# Altium schematic sheet Power.SchDoc(Power) (continued)
## Library data of the parts (continued)
R10 = RC0603JR-07300RL: Res Thick Film 0603 300 Ohm 5% 0.1W(1/10W) ±100ppm/C Pad SMD T/R; Manufacturer: Yageo Group; Power Dissipation: 100mW; Temperature Coefficient: 100ppm/°C; Value: 300Ω; Voltage Rating: 75V; Voltage Rating (DC): 50V; Case Code (Imperial): 0603; Case/Package: 0603; Category: Chip SMD Resistors; China RoHS: Non-Compliant; Component Kind: Standard; ComponentLink1Description: https://datasheet.octopart.com:443/RC0603JR-07300RL-Yageo-datasheet-175887523.pdf?src-supplier=IHS; ComponentLink1URL: https://datasheet.octopart.com:443/RC0603JR-07300RL-Yageo-datasheet-175887523.pdf?src-supplier=IHS; ComponentLink2Description: https://datasheet.octopart.com:443/RC0603JR-07300RL-Yageo-datasheet-98239111.pdf?src-supplier=Upverter; ComponentLink2URL: https://datasheet.octopart.com:443/RC0603JR-07300RL-Yageo-datasheet-98239111.pdf?src-supplier=Upverter; ComponentLink3Description: https://datasheet.octopart.com:443/RC0603JR-07300RL-Yageo-datasheet-8328172.pdf?src-supplier=TME; ComponentLink3URL: https://datasheet.octopart.com:443/RC0603JR-07300RL-Yageo-datasheet-8328172.pdf?src-supplier=TME; ComponentLink4Description: https://datasheet.octopart.com:443/RC0603JR-07300RL-Yageo-datasheet-34211833.pdf?src-supplier=element14+APAC; ComponentLink4URL: https://datasheet.octopart.com:443/RC0603JR-07300RL-Yageo-datasheet-34211833.pdf?src-supplier=element14+APAC; ComponentLink5Description: https://datasheet.octopart.com:443/RC0603JR-07300RL-Yageo-datasheet-62067350.pdf?src-supplier=Farnell; ComponentLink5URL: https://datasheet.octopart.com:443/RC0603JR-07300RL-Yageo-datasheet-62067350.pdf?src-supplier=Farnell; ComponentLink6Description: https://datasheet.octopart.com:443/RC0603JR-07300RL-Yageo-Group-datasheet-176638170.pdf?src-supplier=Future+Electronics; ComponentLink6URL: https://datasheet.octopart.com:443/RC0603JR-07300RL-Yageo-Group-datasheet-176638170.pdf?src-supplier=Future+Electronics; ComponentLink7Description: https://datasheet.octopart.com:443/RC0603JR-07300RL-Yageo-datasheet-164759695.pdf?src-supplier=Future+Electronics; ComponentLink7URL: https://datasheet.octopart.com:443/RC0603JR-07300RL-Yageo-datasheet-164759695.pdf?src-supplier=Future+Electronics; ComponentLink8Description: https://datasheet.octopart.com:443/RC0603JR-07300RL-Yageo-datasheet-137700087.pdf?src-supplier=Future+Electronics; ComponentLink8URL: https://datasheet.octopart.com:443/RC0603JR-07300RL-Yageo-datasheet-137700087.pdf?src-supplier=Future+Electronics; ComponentLink9Description: https://datasheet.octopart.com:443/RC0603JR-07300RL-Yageo-datasheet-15599030.pdf?src-supplier=Future+Electronics; ComponentLink9URL: https://datasheet.octopart.com:443/RC0603JR-07300RL-Yageo-datasheet-15599030.pdf?src-supplier=Future+Electronics; ComponentLink10Description: https://datasheet.octopart.com:443/RC0603JR-07300RL-Yageo-datasheet-12596169.pdf?src-supplier=Future+Electronics; ComponentLink10URL: https://datasheet.octopart.com:443/RC0603JR-07300RL-Yageo-datasheet-12596169.pdf?src-supplier=Future+Electronics; ComponentLink11Description: https://datasheet.octopart.com:443/RC0603JR-07300RL-Yageo-datasheet-8324193.pdf?src-supplier=Future+Electronics; ComponentLink11URL: https://datasheet.octopart.com:443/RC0603JR-07300RL-Yageo-datasheet-8324193.pdf?src-supplier=Future+Electronics; ComponentLink12Description: https://datasheet.octopart.com/RC0603JR-07300RL-Yageo-datasheet-73766026.pdf; ComponentLink12URL: https://datasheet.octopart.com/RC0603JR-07300RL-Yageo-datasheet-73766026.pdf; ComponentLink13Description: https://datasheet.octopart.com:443/RC0603JR-07300RL-Yageo-datasheet-118562.pdf?src-supplier=DigiKey; ComponentLink13URL: https://datasheet.octopart.com:443/RC0603JR-07300RL-Yageo-datasheet-118562.pdf?src-supplier=DigiKey; ComponentLink14Description: http://sigma.octopart.com:80/conflict_mineral_statement/Yageo-company-3.pdf?src-supplier=Compliance+Statements; ComponentLink14URL: http://sigma.octopart.com:80/conflict_mineral_statement/Yageo-company-3.pdf?src-supplier=Compliance+Statements; ComponentLink15Description: http://sigma.octopart.com:80/rohs_statement/Yageo-company-2.pdf?src-supplier=Compliance+Statements; ComponentLink15URL: http://sigma.octopart.com:80/rohs_statement/Yageo-company-2.pdf?src-supplier=Compliance+Statements; Composition: Thick Film; Country of Origin: Mainland China, Taiwan; Depth: 800µm; Export Control Classification Number (ECCN) Code: EAR99; Harmonized Tariff Schedule (HTS) Code: 8533.21.00.30; Height: 450µm; Introduction Date: 2004-09-22; Lead Free: Lead Free; Length: 1.6mm; Library Name: ENGG3800 schematic library.SchLib; Lifecycle Status: Production; Manufacturer Part Number: RC0603JR-07300RL; Max Operating Temperature: 155°C; Military Standard: Not; Min Operating Temperature: -55°C; Mounting Technology: Surface Mount; Number of Terminals: 2; Pins: 2; Power Rating: 100mW; Pricing: 5000=0.0015, 10000=0.0012 (USD); REACH SVHC: Yes; RoHS: Compliant; Schedule B: 8533210045, 8533210045|8533210045|8533210045|8533210045|8533210045|8533210045|8533210045|8533210045|8533210045|8533210045|8533210045|8533210045|8533210045|8533210045|8533210045|8533210045|8533210045|8533210045|8533210045|8533210045|8533210045|8533210045|8533210045|85; Stock: 640000; Supplier 1: Digikey; Supplier Part Number 1: 311-300GRCT-ND; Termination: SMD/SMT; Weight: 2.012816mg; Width: 800µm; Working Voltage: 75V
SW1 = CS22BNW03: Switch Slide ON None ON DPDT Top Slide 3A 250VAC 50000Cycles PC Pins Thru-Hole; Manufacturer: NKK Switches; Actuator Length: 5.1054mm; Actuator Material: Glass, Glass fibre, Nylon, Polyamide; Contact Resistance: 10mΩ; Contact Style: Non-Shorting; Contact Voltage Rating (AC): 125V; Electrical Life: 20kcycles; Illumination Type: Non-Illuminated; Insulation Resistance: 1GΩ; Max Voltage Rating (AC): 250V; Mechanical Life: 50kcycles; Number of Positions: 2; Sealable: EPOXY TERMINAL SEALED; Switch Function: On-On; Switch Travel: 2.2mm; Category: Slide Switches; China RoHS: Compliant; Component Kind: Standard; ComponentLink1Description: https://datasheet.octopart.com:443/CS22BNW03-NKK-Switches-datasheet-12526506.pdf?src-supplier=Upverter; ComponentLink1URL: https://datasheet.octopart.com:443/CS22BNW03-NKK-Switches-datasheet-12526506.pdf?src-supplier=Upverter; ComponentLink2Description: https://datasheet.octopart.com:443/CS22BNW03-NKK-Switches-datasheet-123473.pdf?src-supplier=Future+Electronics; ComponentLink2URL: https://datasheet.octopart.com:443/CS22BNW03-NKK-Switches-datasheet-123473.pdf?src-supplier=Future+Electronics; ComponentLink3Description: https://datasheet.octopart.com:443/CS22BNW03-NKK-Switches-datasheet-110754214.pdf?src-supplier=TME; ComponentLink3URL: https://datasheet.octopart.com:443/CS22BNW03-NKK-Switches-datasheet-110754214.pdf?src-supplier=TME; ComponentLink4Description: https://datasheet.octopart.com:443/CS22BNW03-NKK-Switches-datasheet-137092470.pdf?src-supplier=X-ON; ComponentLink4URL: https://datasheet.octopart.com:443/CS22BNW03-NKK-Switches-datasheet-137092470.pdf?src-supplier=X-ON; ComponentLink5Description: http://sigma.octopart.com:80/rohs_statement/NKK-Switches-company-77.pdf?src-supplier=Compliance+Statements; ComponentLink5URL: http://sigma.octopart.com:80/rohs_statement/NKK-Switches-company-77.pdf?src-supplier=Compliance+Statements; ComponentLink6Description: http://sigma.octopart.com:80/reach_statement/NKK-Switches-company-76.pdf?src-supplier=Compliance+Statements; ComponentLink6URL: http://sigma.octopart.com:80/reach_statement/NKK-Switches-company-76.pdf?src-supplier=Compliance+Statements; ComponentLink7Description: http://sigma.octopart.com:80/conflict_mineral_statement/NKK-Switches-company-75.pdf?src-supplier=Compliance+Statements; ComponentLink7URL: http://sigma.octopart.com:80/conflict_mineral_statement/NKK-Switches-company-75.pdf?src-supplier=Compliance+Statements; Contact Current Rating: 3A; Contact Material: Copper; Contact Plating: Silver; Current Rating: 3A; Depth: 11.9mm; Export Control Classification Number (ECCN) Code: EAR99; Flammability Rating: UL94 V-0; Harmonized Tariff Schedule (HTS) Code: 8536.50.90.50; Height: 9.7mm; Introduction Date: 2010-05-04; Lead Free: Lead Free; Length: 14mm; Library Name: ENGG3800 schematic library.SchLib; Life (Cycles): 20kcycles; Lifecycle Status: Production; Manufacturer Part Number: CS22BNW03; Max Current Rating: 3A; Max Operating Temperature: 85°C; Min Operating Temperature: -30°C; Mounting Technology: Through Hole; Orientation: Vertical; Pricing: 50=1.47, 150=1.46, 500=1.44, 1000=1.42, 2000=1.4 (USD); REACH SVHC: Yes; RoHS: Compliant; Stock: 789; Supplier 1: Digikey; Supplier Part Number 1: 360-2132-ND; Terminal Material: Copper; Termination: PC Pins; Throw Configuration: DPDT; Voltage Rating (AC): 125V
TP1 = 5010: MS27478 - Connectors Receptacle Electrical Solder Mounting Solder Type Hermetic Seal 38999 Series II; Manufacturer: Keystone Electronics; Body Material: Bronze,PBT; Hole Diameter: 1.6mm; Inside Diameter: 1.78mm; Plating: Silver; Category: Connectors; Color: Red; Component Kind: Standard; ComponentLink1Description: https://datasheet.ciiva.com/11744/0900766b8009b7c7-11744920.pdf?src-supplier=Rs; ComponentLink1URL: https://datasheet.ciiva.com/11744/0900766b8009b7c7-11744920.pdf?src-supplier=Rs; ComponentLink2Description: https://datasheet.ciiva.com/2917/5010-2917626.pdf?src-supplier=Digikey; ComponentLink2URL: https://datasheet.ciiva.com/2917/5010-2917626.pdf?src-supplier=Digikey; ComponentLink3Description: https://datasheet.ciiva.com/1454/1703983-1454833.pdf?src-supplier=Element14; ComponentLink3URL: https://datasheet.ciiva.com/1454/1703983-1454833.pdf?src-supplier=Element14; ComponentLink4Description: https://datasheet.ciiva.com/22631/1703983-22631113.pdf?src-supplier=Element14; ComponentLink4URL: https://datasheet.ciiva.com/22631/1703983-22631113.pdf?src-supplier=Element14; ComponentLink5Description: https://datasheet.ciiva.com/16506/m60-2p60-16506972.pdf?src-supplier=Future+Electronics; ComponentLink5URL: https://datasheet.ciiva.com/16506/m60-2p60-16506972.pdf?src-supplier=Future+Electronics; ComponentLink6Description: https://datasheet.ciiva.com/16883/70182825-16883886.pdf?src-supplier=Allied+Electronics; ComponentLink6URL: https://datasheet.ciiva.com/16883/70182825-16883886.pdf?src-supplier=Allied+Electronics; Contact Material: Bronze; Contact Plating: Silver,Tin; Flammability Rating: UL94 V-0; Height: 0.445inch; Insulation Material: Nylon; Lead Free: Lead Free; Length: 11.3mm; Manufacturer Part Number: 5010; Material: Nylon,Bronze,Silver; Mounting Technology: Through Hole,PCB; Package Quantity: 100; Packaging: Bulk; Pricing: 1=0.39, 10=0.293, 25=0.2624, 100=0.2223, 500=0.18446, 1000=0.1739, 2500=0.1542, 5000=0.14312 (USD); Reach SVHC Compliant: No; RoHS: Yes; RoHS Compliant: Yes; Series: THM; Stock: 151577; Supplier 1: Digikey; Supplier Part Number 1: 36-5010-ND; Termination: Through Hole; Library Name: tpmania.SchLib
TP2 = 5012: Test Point, Multipurpose, Thm, .350 L, .125 Od, Heat Resistant Nylon 46, White; Manufacturer: Keystone; Body Material: Bronze, PBT; Gender: Female; Hole Diameter: 1.6mm; Inside Diameter: 1.78mm; Insulation: Insulated; Number of Contacts: 1; Case/Package: 5012; Category: Test Points; Color: White; Component Kind: Standard; ComponentLink1Description: https://datasheet.octopart.com:443/5012-Keystone-datasheet-111020743.pdf?src-supplier=IHS; ComponentLink1URL: https://datasheet.octopart.com:443/5012-Keystone-datasheet-111020743.pdf?src-supplier=IHS; ComponentLink2Description: https://datasheet.octopart.com:443/5012-Keystone-datasheet-15716159.pdf?src-supplier=Farnell; ComponentLink2URL: https://datasheet.octopart.com:443/5012-Keystone-datasheet-15716159.pdf?src-supplier=Farnell; ComponentLink3Description: https://datasheet.octopart.com:443/5012-Keystone-datasheet-9590016.pdf?src-supplier=Sager+Electronics; ComponentLink3URL: https://datasheet.octopart.com:443/5012-Keystone-datasheet-9590016.pdf?src-supplier=Sager+Electronics; ComponentLink4Description: https://datasheet.octopart.com:443/5012-Keystone-datasheet-23674.pdf?src-supplier=element14; ComponentLink4URL: https://datasheet.octopart.com:443/5012-Keystone-datasheet-23674.pdf?src-supplier=element14; ComponentLink5Description: https://datasheet.octopart.com:443/5012-Keystone-datasheet-549598.pdf?src-supplier=Future+Electronics; ComponentLink5URL: https://datasheet.octopart.com:443/5012-Keystone-datasheet-549598.pdf?src-supplier=Future+Electronics; ComponentLink6Description: https://datasheet.octopart.com:443/5012-Keystone-datasheet-60278094.pdf?src-supplier=Future+Electronics; ComponentLink6URL: https://datasheet.octopart.com:443/5012-Keystone-datasheet-60278094.pdf?src-supplier=Future+Electronics; ComponentLink7Description: https://datasheet.octopart.com:443/5012-Keystone-datasheet-528293.pdf?src-supplier=Future+Electronics; ComponentLink7URL: https://datasheet.octopart.com:443/5012-Keystone-datasheet-528293.pdf?src-supplier=Future+Electronics; ComponentLink8Description: https://datasheet.octopart.com:443/5012-Keystone-datasheet-10925273.pdf?src-supplier=RS+(Formerly+Allied+Electronics); ComponentLink8URL: https://datasheet.octopart.com:443/5012-Keystone-datasheet-10925273.pdf?src-supplier=RS+(Formerly+Allied+Electronics); ComponentLink9Description: https://datasheet.octopart.com:443/5012-Keystone-datasheet-6528.pdf?src-supplier=RS+(Formerly+Allied+Electronics); ComponentLink9URL: https://datasheet.octopart.com:443/5012-Keystone-datasheet-6528.pdf?src-supplier=RS+(Formerly+Allied+Electronics); ComponentLink10Description: https://datasheet.octopart.com:443/5012-Keystone-datasheet-7282928.pdf?src-supplier=Jameco; ComponentLink10URL: https://datasheet.octopart.com:443/5012-Keystone-datasheet-7282928.pdf?src-supplier=Jameco; Contact Material: Bronze; Contact Plating: Silver; Country of Origin: Mainland China; Diameter: 3.18mm; Export Control Classification Number (ECCN) Code: EAR99; Flammability Rating: UL94 V-0; Height: 8.12mm; Housing Color: White; Introduction Date: 1995-10-01; Lead Free: Lead Free; Length: 11.3mm; Lifecycle Status: Production; Manufacturer Part Number: 5012; Material: Bronze, Nylon, Silver; Mounting Technology: Through Hole; Number of Ports: 1; Orientation: Straight; Packaging: Bulk; Pricing: 125=0.184, 250=0.181, 500=0.178, 1250=0.175, 2500=0.17 (USD); REACH SVHC: Yes; RoHS: Compliant; Stock: 1107; Supplier 1: Digikey; Supplier Part Number 1: 36-5012-ND; Termination: Through Hole; Library Name: tpmania.SchLib
TP3 = 5013: Test Point, Multipurpose, Thm, .350 L, .125 Od, Heat Resistant Nylon 46, Orange; Manufacturer: Keystone; Body Material: PBT; Inside Diameter: 1.78mm; Category: Test Points; Color: Orange; Component Kind: Standard; ComponentLink1Description: https://datasheet.octopart.com:443/5013-Keystone-datasheet-111020744.pdf?src-supplier=IHS; ComponentLink1URL: https://datasheet.octopart.com:443/5013-Keystone-datasheet-111020744.pdf?src-supplier=IHS; ComponentLink2Description: https://datasheet.octopart.com:443/5013-Keystone-datasheet-15716159.pdf?src-supplier=Farnell; ComponentLink2URL: https://datasheet.octopart.com:443/5013-Keystone-datasheet-15716159.pdf?src-supplier=Farnell; ComponentLink3Description: https://datasheet.octopart.com:443/5013-Keystone-datasheet-23674.pdf?src-supplier=element14; ComponentLink3URL: https://datasheet.octopart.com:443/5013-Keystone-datasheet-23674.pdf?src-supplier=element14; ComponentLink4Description: https://datasheet.octopart.com:443/5013-Keystone-datasheet-9590016.pdf?src-supplier=Sager+Electronics; ComponentLink4URL: https://datasheet.octopart.com:443/5013-Keystone-datasheet-9590016.pdf?src-supplier=Sager+Electronics; ComponentLink5Description: https://datasheet.octopart.com:443/5013-Keystone-datasheet-60278094.pdf?src-supplier=Future+Electronics; ComponentLink5URL: https://datasheet.octopart.com:443/5013-Keystone-datasheet-60278094.pdf?src-supplier=Future+Electronics; ComponentLink6Description: https://datasheet.octopart.com:443/5013-Keystone-datasheet-549598.pdf?src-supplier=Future+Electronics; ComponentLink6URL: https://datasheet.octopart.com:443/5013-Keystone-datasheet-549598.pdf?src-supplier=Future+Electronics; ComponentLink7Description: https://datasheet.octopart.com:443/5013-Keystone-datasheet-10925273.pdf?src-supplier=RS+(Formerly+Allied+Electronics); ComponentLink7URL: https://datasheet.octopart.com:443/5013-Keystone-datasheet-10925273.pdf?src-supplier=RS+(Formerly+Allied+Electronics); ComponentLink8Description: https://datasheet.octopart.com:443/5013-Keystone-datasheet-6528.pdf?src-supplier=RS+(Formerly+Allied+Electronics); ComponentLink8URL: https://datasheet.octopart.com:443/5013-Keystone-datasheet-6528.pdf?src-supplier=RS+(Formerly+Allied+Electronics); ComponentLink9Description: https://datasheet.octopart.com:443/5013-Keystone-datasheet-34151652.pdf?src-supplier=TME; ComponentLink9URL: https://datasheet.octopart.com:443/5013-Keystone-datasheet-34151652.pdf?src-supplier=TME; Contact Material: Bronze; Contact Plating: Silver; Country of Origin: Mainland China; Export Control Classification Number (ECCN) Code: EAR99; Flammability Rating: UL94 V-0; Height: 8.12mm; Introduction Date: 1995-10-01; Lead Free: Lead Free; Length: 11.3mm; Lifecycle Status: Production; Manufacturer Part Number: 5013; Material: Bronze, Nylon, Silver; Mounting Technology: Through Hole; Packaging: Bulk; Pricing: 125=0.173, 250=0.171, 500=0.169, 1500=0.165, 3000=0.16 (USD); REACH SVHC: Yes; RoHS: Compliant; Stock: 2691; Supplier 1: Digikey; Supplier Part Number 1: 36-5013-ND; Termination: Through Hole; Library Name: tpmania.SchLib
TP4 = 5014: MS27478 - Connectors Receptacle Electrical Solder Mounting Solder Type Hermetic Seal 38999 Series II; Manufacturer: Keystone Electronics; Body Material: Bronze,PBT; Inside Diameter: 1.78mm; Plating: Silver; Category: Connectors; Color: Yellow; Component Kind: Standard; ComponentLink1Description: https://datasheet.ciiva.com/2917/5014-2917636.pdf?src-supplier=Digikey; ComponentLink1URL: https://datasheet.ciiva.com/2917/5014-2917636.pdf?src-supplier=Digikey; ComponentLink2Description: https://datasheet.ciiva.com/22631/1703983-22631119.pdf?src-supplier=Element14; ComponentLink2URL: https://datasheet.ciiva.com/22631/1703983-22631119.pdf?src-supplier=Element14; ComponentLink3Description: https://datasheet.ciiva.com/16297/m60-2p60-16297225.pdf?src-supplier=Future+Electronics; ComponentLink3URL: https://datasheet.ciiva.com/16297/m60-2p60-16297225.pdf?src-supplier=Future+Electronics; ComponentLink4Description: https://datasheet.ciiva.com/16884/70182825-16884159.pdf?src-supplier=Allied+Electronics; ComponentLink4URL: https://datasheet.ciiva.com/16884/70182825-16884159.pdf?src-supplier=Allied+Electronics; Contact Material: Bronze; Contact Plating: Silver,Tin; Flammability Rating: UL94 V-0; Height: 0.445inch; Insulation Material: Nylon; Lead Free: Lead Free; Length: 11.3mm; Manufacturer Part Number: 5014; Material: Nylon,Bronze,Silver; Mounting Technology: PCB,Through Hole; Package Quantity: 100; Packaging: Bulk; Pricing: 1=0.39, 10=0.293, 25=0.2624, 100=0.2223, 500=0.18446, 1000=0.1739, 2500=0.1542, 5000=0.14312 (USD); Reach SVHC Compliant: No; RoHS: Yes; RoHS Compliant: Yes; Series: THM; Stock: 92393; Supplier: Digikey; Supplier Part Number: 36-5014-ND; Termination: Through Hole; Library Name: tpmania.SchLib
TP5 = 5011: TEST POINT, PCB, THROUGH HOLE; Product R; Range: -; Connector Colour: Black; Mounting: Through Hole; Manufacturer: Keystone Electronics; Body Material: PBT; Gender: Female; Hole Diameter: 1.6mm; Inside Diameter: 1.78mm; Category: Connectors; Color: Black; Component Kind: Standard; ComponentLink1Description: https://datasheet.ciiva.com/1454/1718230-1454834.pdf?src-supplier=Element14; ComponentLink1URL: https://datasheet.ciiva.com/1454/1718230-1454834.pdf?src-supplier=Element14; ComponentLink2Description: https://datasheet.ciiva.com/11744/0900766b8009b7c7-11744935.pdf?src-supplier=Rs; ComponentLink2URL: https://datasheet.ciiva.com/11744/0900766b8009b7c7-11744935.pdf?src-supplier=Rs; ComponentLink3Description: https://datasheet.ciiva.com/2917/5011-2917635.pdf?src-supplier=Digikey; ComponentLink3URL: https://datasheet.ciiva.com/2917/5011-2917635.pdf?src-supplier=Digikey; ComponentLink4Description: https://datasheet.ciiva.com/46412/1703983-46412138.pdf?src-supplier=Element14; ComponentLink4URL: https://datasheet.ciiva.com/46412/1703983-46412138.pdf?src-supplier=Element14; ComponentLink5Description: https://datasheet.ciiva.com/16351/m60-2p60-16351093.pdf?src-supplier=Future+Electronics; ComponentLink5URL: https://datasheet.ciiva.com/16351/m60-2p60-16351093.pdf?src-supplier=Future+Electronics; Contact Material: Bronze; Contact Plating: Tin,Silver; Flammability Rating: UL94 V-0; Lead Free: 5697LeadFree; Length: 11.3mm; Manufacturer Part Number: 5011; Material: Nylon,Bronze,Silver; Mounting Technology: PCB,Through Hole; Package Quantity: 100; Packaging: Bulk; Pricing: 1=0.39, 10=0.293, 25=0.2624, 100=0.2223, 500=0.18446, 1000=0.1739, 2500=0.1542, 5000=0.14312 (USD); Reach SVHC Compliant: No; RoHS: Yes; RoHS Compliant: Yes; Series: THM; Stock: 252020; Supplier: Digikey; Supplier Part Number: 36-5011-ND; Library Name: tpmania.SchLib
U2 = MCP73831T-2ACI/OT: MCP73831 Series Single-Cell Li-Ion/Li-Polymer Battery Charge Controller SOT-23-5; Manufacturer: Microchip; Manufacturer Lifecycle Status: REL; Drain to Source Resistance: 350mΩ; Max Charge Current: 500mA; Max Junction Temperature (Tj): 125°C; Max Output Voltage: 4.5V; Max Power Dissipation: 1.54W; Max Supply Voltage: 6V; Min Output Voltage: 4.168V; Min Supply Voltage: 3.75V; Number of Cells: 1; Output Voltage: 4.2V; Voltage Rating: 4.534V; Ambient Temperature Range High: 85°C; Case/Package: SOT-23; Category: Battery Management; Component Kind: Standard; ComponentLink1Description: https://datasheet.octopart.com:443/MCP73831T-2ACI-OT-Microchip-datasheet-8814540.pdf?src-supplier=element14+APAC; ComponentLink1URL: https://datasheet.octopart.com:443/MCP73831T-2ACI-OT-Microchip-datasheet-8814540.pdf?src-supplier=element14+APAC; ComponentLink2Description: https://datasheet.octopart.com:443/MCP73831T-2ACI-OT-Microchip-datasheet-145017548.pdf?src-supplier=Microchip; ComponentLink2URL: https://datasheet.octopart.com:443/MCP73831T-2ACI-OT-Microchip-datasheet-145017548.pdf?src-supplier=Microchip; ComponentLink3Description: https://datasheet.octopart.com:443/MCP73831T-2ACI-OT-Microchip-datasheet-34193478.pdf?src-supplier=Farnell; ComponentLink3URL: https://datasheet.octopart.com:443/MCP73831T-2ACI-OT-Microchip-datasheet-34193478.pdf?src-supplier=Farnell; ComponentLink4Description: https://datasheet.octopart.com:443/MCP73831T-2ACI-OT-Microchip-datasheet-16123459.pdf?src-supplier=LKR; ComponentLink4URL: https://datasheet.octopart.com:443/MCP73831T-2ACI-OT-Microchip-datasheet-16123459.pdf?src-supplier=LKR; ComponentLink5Description: https://datasheet.octopart.com:443/MCP73831T-2ACI-OT-Microchip-datasheet-142675560.pdf?src-supplier=TME; ComponentLink5URL: https://datasheet.octopart.com:443/MCP73831T-2ACI-OT-Microchip-datasheet-142675560.pdf?src-supplier=TME; ComponentLink6Description: https://datasheet.octopart.com:443/MCP73831T-2ACI-OT-Microchip-datasheet-158592061.pdf?src-supplier=SHENGYU+ELECTRONICS; ComponentLink6URL: https://datasheet.octopart.com:443/MCP73831T-2ACI-OT-Microchip-datasheet-158592061.pdf?src-supplier=SHENGYU+ELECTRONICS; ComponentLink7Description: https://datasheet.octopart.com/MCP73831T-2ACI-OT-Microchip-datasheet-16593012.pdf; ComponentLink7URL: https://datasheet.octopart.com/MCP73831T-2ACI-OT-Microchip-datasheet-16593012.pdf; ComponentLink8Description: https://datasheet.octopart.com:443/MCP73831T-2ACI-OT-Microchip-datasheet-7127743.pdf?src-supplier=Newark; ComponentLink8URL: https://datasheet.octopart.com:443/MCP73831T-2ACI-OT-Microchip-datasheet-7127743.pdf?src-supplier=Newark; ComponentLink9Description: https://datasheet.octopart.com:443/MCP73831T-2ACIOT-Microchip-datasheet-39874.pdf?src-supplier=RS+(Formerly+Allied+Electronics); ComponentLink9URL: https://datasheet.octopart.com:443/MCP73831T-2ACIOT-Microchip-datasheet-39874.pdf?src-supplier=RS+(Formerly+Allied+Electronics); ComponentLink10Description: http://sigma.octopart.com:80/188449423/materials_sheet/Microchip-MCP73831T-2ACI-OT.pdf?src-supplier=Compliance+Statements; ComponentLink10URL: http://sigma.octopart.com:80/188449423/materials_sheet/Microchip-MCP73831T-2ACI-OT.pdf?src-supplier=Compliance+Statements; ComponentLink11Description: http://sigma.octopart.com:80/192856963/materials_sheet/Microchip-MCP73831T-2ACI-OT.pdf?src-supplier=Compliance+Statements; ComponentLink11URL: http://sigma.octopart.com:80/192856963/materials_sheet/Microchip-MCP73831T-2ACI-OT.pdf?src-supplier=Compliance+Statements; ComponentLink12Description: http://sigma.octopart.com:80/rohs_statement/Microchip-company-71.pdf?src-supplier=Compliance+Statements; ComponentLink12URL: http://sigma.octopart.com:80/rohs_statement/Microchip-company-71.pdf?src-supplier=Compliance+Statements; ComponentLink13Description: http://sigma.octopart.com:80/reach_statement/Microchip-company-70.pdf?src-supplier=Compliance+Statements; ComponentLink13URL: http://sigma.octopart.com:80/reach_statement/Microchip-company-70.pdf?src-supplier=Compliance+Statements; ComponentLink14Description: http://sigma.octopart.com:80/conflict_mineral_statement/Microchip-company-69.pdf?src-supplier=Compliance+Statements; ComponentLink14URL: http://sigma.octopart.com:80/conflict_mineral_statement/Microchip-company-69.pdf?src-supplier=Compliance+Statements; ComponentLink15Description: http://sigma.octopart.com:80/conflict_mineral_statement/Microchip-company-68.pdf?src-supplier=Compliance+Statements; ComponentLink15URL: http://sigma.octopart.com:80/conflict_mineral_statement/Microchip-company-68.pdf?src-supplier=Compliance+Statements; Contact Plating: Tin; Country of Assembly: Thailand; Country of Fabrication: United States of America; Country of Origin: Thailand; Export Control Classification Number (ECCN) Code: EAR99; Harmonized Tariff Schedule (HTS) Code: 8542.39.0060; Height: 1.45mm; Introduction Date: 2005-11-03; Length: 3.0988mm; Library Name: ENGG3800 schematic library.SchLib; Lifecycle Status: Production; Manufacturer Part Number: MCP73831T-2ACI/OT; Max Operating Temperature: 85°C; Min Operating Temperature: -40°C; Mounting Technology: Surface Mount; Nominal Supply Voltage: 6V; Number of Channels: 1; Number of Outputs: 1; Output Current: 500mA; Packaging: Cut Tape; Pins: 5; Pricing: 3000=0.99, 6000=0.985, 12000=0.98, 24000=0.975, 48000=0.97 (USD); Projected EOL Date: 2034-11-03; Radiation Hardening: No; REACH SVHC: No; RoHS: Compliant; Schedule B: 8542390000, 8542390000|8542390000|8542390000|8542390000|8542390000; Stock: 21000; Supplier 1: Digikey; Supplier Part Number 1: MCP73831T-2ACI/OTCT-ND; Termination: SMD/SMT; Weight: 200.998119mg; Width: 1.8034mm
U3 = TPS63001DRCR: 96% Buck-Boost Converter with 1.7A Current Switches, 3.3V fixed Output voltage in 3x3 QFN 10-VSON -40 to 125; Manufacturer: Texas Instruments; Manufacturer Lifecycle Status: ACTIVE; Max Duty Cycle: 100%; Max Junction Temperature (Tj): 125°C; Max Output current: 1.2A; Max Supply Voltage: 5.5V; Min Input Voltage: 1.8V; Number of Channels: 1; Quiescent Current: 30µA; Switching Frequency: 1.5MHz; Synchronous Rectifier: Yes; Ambient Temperature Range High: 85°C; Category: Voltage Regulators - Switching; Component Kind: Standard; ComponentLink1Description: https://sigma.octopart.com:443/104365911/technical_drawing/Texas-Instruments-TPS63001DRCR.pdf?src-supplier=Texas+Instruments; ComponentLink1URL: https://sigma.octopart.com:443/104365911/technical_drawing/Texas-Instruments-TPS63001DRCR.pdf?src-supplier=Texas+Instruments; ComponentLink2Description: https://octopart.com:443/opatz8j6/c1?t=CeUKE_jHOOYuuVveIi8QcN3ZZ4M_wMKQnj49uxiL2evaLs4j02-YrkACWP1vyhJr204HzQ4WkUXhvtJ-DA6tyoTZI5pW9Gu0ySX7ZcPhvfiT_lAxbFL7a7Akla9lNBoCqCpqow0EbzaT66h06JcZmi9QuLZTTzVK7Lf3RF51fPctnTHJpkVVwYOPCxiJvAIlCheF2te3t5MUJtJJnmMVBdKHL9iao_NpZ2L4OAuJE8NGT8xljIt4Up3TToa2GB94EDIPoISznRzP-hIJ8mTUe5UdM9oCRVYOsKTGdMZ3g94y7mTRsvZhG-Mp4dAcS09AWuTY00ysGwLbb1mXLtgLiC3LJImeLClDVD6Th5WrDqC1CngZfhJnhicVc9eNxKRXT0SGbg&src-supplier=Texas+Instruments; ComponentLink2URL: https://octopart.com:443/opatz8j6/c1?t=CeUKE_jHOOYuuVveIi8QcN3ZZ4M_wMKQnj49uxiL2evaLs4j02-YrkACWP1vyhJr204HzQ4WkUXhvtJ-DA6tyoTZI5pW9Gu0ySX7ZcPhvfiT_lAxbFL7a7Akla9lNBoCqCpqow0EbzaT66h06JcZmi9QuLZTTzVK7Lf3RF51fPctnTHJpkVVwYOPCxiJvAIlCheF2te3t5MUJtJJnmMVBdKHL9iao_NpZ2L4OAuJE8NGT8xljIt4Up3TToa2GB94EDIPoISznRzP-hIJ8mTUe5UdM9oCRVYOsKTGdMZ3g94y7mTRsvZhG-Mp4dAcS09AWuTY00ysGwLbb1mXLtgLiC3LJImeLClDVD6Th5WrDqC1CngZfhJnhicVc9eNxKRXT0SGbg&src-supplier=Texas+Instruments; ComponentLink3Description: https://datasheet.octopart.com:443/TPS63001DRCR-Texas-Instruments-datasheet-33460674.pdf?src-supplier=Texas+Instruments; ComponentLink3URL: https://datasheet.octopart.com:443/TPS63001DRCR-Texas-Instruments-datasheet-33460674.pdf?src-supplier=Texas+Instruments; ComponentLink4Description: https://sigma.octopart.com:443/49250247/technical_drawing/Texas-Instruments-TPS63001DRCR.pdf?src-supplier=Texas+Instruments; ComponentLink4URL: https://sigma.octopart.com:443/49250247/technical_drawing/Texas-Instruments-TPS63001DRCR.pdf?src-supplier=Texas+Instruments; ComponentLink5Description: https://datasheet.octopart.com:443/TPS63001DRCR-Texas-Instruments-datasheet-10258521.pdf?src-supplier=Texas+Instruments; ComponentLink5URL: https://datasheet.octopart.com:443/TPS63001DRCR-Texas-Instruments-datasheet-10258521.pdf?src-supplier=Texas+Instruments; ComponentLink6Description: https://datasheet.octopart.com:443/TPS63001DRCR-Texas-Instruments-datasheet-148853.pdf?src-supplier=Texas+Instruments; ComponentLink6URL: https://datasheet.octopart.com:443/TPS63001DRCR-Texas-Instruments-datasheet-148853.pdf?src-supplier=Texas+Instruments; ComponentLink7Description: https://datasheet.octopart.com:443/TPS63001DRCR-Texas-Instruments-datasheet-10257500.pdf?src-supplier=Texas+Instruments; ComponentLink7URL: https://datasheet.octopart.com:443/TPS63001DRCR-Texas-Instruments-datasheet-10257500.pdf?src-supplier=Texas+Instruments; ComponentLink8Description: https://datasheet.octopart.com:443/TPS63001DRCR-Texas-Instruments-datasheet-82285885.pdf?src-supplier=Newark; ComponentLink8URL: https://datasheet.octopart.com:443/TPS63001DRCR-Texas-Instruments-datasheet-82285885.pdf?src-supplier=Newark; ComponentLink9Description: https://datasheet.octopart.com:443/TPS63001DRCR-Texas-Instruments-datasheet-62118876.pdf?src-supplier=Newark; ComponentLink9URL: https://datasheet.octopart.com:443/TPS63001DRCR-Texas-Instruments-datasheet-62118876.pdf?src-supplier=Newark; ComponentLink10Description: https://datasheet.octopart.com:443/TPS63001DRCR-Texas-Instruments-datasheet-11537794.pdf?src-supplier=Upverter; ComponentLink10URL: https://datasheet.octopart.com:443/TPS63001DRCR-Texas-Instruments-datasheet-11537794.pdf?src-supplier=Upverter; ComponentLink11Description: https://datasheet.octopart.com:443/TPS63001DRCR-Texas-Instruments-datasheet-33012753.pdf?src-supplier=element14+APAC; ComponentLink11URL: https://datasheet.octopart.com:443/TPS63001DRCR-Texas-Instruments-datasheet-33012753.pdf?src-supplier=element14+APAC; ComponentLink12Description: https://datasheet.octopart.com:443/TPS63001DRCR-Texas-Instruments-datasheet-165804847.pdf?src-supplier=LCSC; ComponentLink12URL: https://datasheet.octopart.com:443/TPS63001DRCR-Texas-Instruments-datasheet-165804847.pdf?src-supplier=LCSC; ComponentLink13Description: https://datasheet.octopart.com:443/TPS63001DRCR-Texas-Instruments-datasheet-159118221.pdf?src-supplier=LCSC; ComponentLink13URL: https://datasheet.octopart.com:443/TPS63001DRCR-Texas-Instruments-datasheet-159118221.pdf?src-supplier=LCSC; ComponentLink14Description: https://datasheet.octopart.com:443/TPS63001DRCR-Texas-Instruments-datasheet-14167060.pdf?src-supplier=iiiC; ComponentLink14URL: https://datasheet.octopart.com:443/TPS63001DRCR-Texas-Instruments-datasheet-14167060.pdf?src-supplier=iiiC; ComponentLink15Description: https://datasheet.octopart.com:443/TPS63001DRCR-Texas-Instruments-datasheet-11551953.pdf?src-supplier=Arrow+Electronics; ComponentLink15URL: https://datasheet.octopart.com:443/TPS63001DRCR-Texas-Instruments-datasheet-11551953.pdf?src-supplier=Arrow+Electronics; ComponentLink16Description: https://datasheet.octopart.com:443/TPS63001DRCR-Texas-Instruments-datasheet-8770310.pdf?src-supplier=element14; ComponentLink16URL: https://datasheet.octopart.com:443/TPS63001DRCR-Texas-Instruments-datasheet-8770310.pdf?src-supplier=element14; ComponentLink17Description: https://datasheet.octopart.com:443/TPS63001DRCR-Texas-Instruments-datasheet-8699704.pdf?src-supplier=element14; ComponentLink17URL: https://datasheet.octopart.com:443/TPS63001DRCR-Texas-Instruments-datasheet-8699704.pdf?src-supplier=element14; ComponentLink18Description: https://datasheet.octopart.com:443/TPS63001DRCR-Texas-Instruments-datasheet-127639.pdf?src-supplier=DigiKey; ComponentLink18URL: https://datasheet.octopart.com:443/TPS63001DRCR-Texas-Instruments-datasheet-127639.pdf?src-supplier=DigiKey; ComponentLink19Description: http://sigma.octopart.com:80/reach_statement/Texas-Instruments-company-64.pdf?src-supplier=Compliance+Statements; ComponentLink19URL: http://sigma.octopart.com:80/reach_statement/Texas-Instruments-company-64.pdf?src-supplier=Compliance+Statements; ComponentLink20Description: http://sigma.octopart.com:80/conflict_mineral_statement/Texas-Instruments-company-63.pdf?src-supplier=Compliance+Statements; ComponentLink20URL: http://sigma.octopart.com:80/conflict_mineral_statement/Texas-Instruments-company-63.pdf?src-supplier=Compliance+Statements; Contact Plating: Gold; Efficiency: 96%; Height: 1mm; Lead Free: Lead Free; Length: 3mm; Library Name: ENGG3800 schematic library.SchLib; Lifecycle Status: Production; Manufacturer Part Number: TPS63001DRCR; Max Operating Temperature: 85°C; Max Output Voltage: 3.3V; Min Operating Temperature: -40°C; Min Output Voltage: 3.3V; Min Supply Voltage: 1.8V; Nominal Output Voltage: 3.3V; Number of Outputs: 1; Operating Supply Voltage: 5.5V; Output Current: 1.2A; Output Type: Fixed; Output Voltage: 3.3V; Packaging: Cut Tape; Pins: 10; Pricing: 1=2.409, 10=1.787, 25=1.618, 100=1.44, 250=1.35, 500=1.289, 1000=1.249 (USD); Radiation Hardening: No; REACH SVHC: No; RoHS: Compliant; Schedule B: 8542390000, 8542390000|8542390000|8542390000|8542390000|8542390000; Stock: 2889; Supplier: Arrow Electronics; Supplier Part Number: TPS63001DRCR; Thickness: 900µm; Topology: Buck-Boost; Weight: 26.109911mg; Width: 3mm
U4 = OPA2330AIDR: Dual, 1.8-V, 35-µA, micropower, precision, zero drift CMOS op amp 8-SOIC -40 to 125; Manufacturer: Texas Instruments; Manufacturer Lifecycle Status: ACTIVE; Bandwidth: 350kHz; Common Mode Rejection Ratio: 100dB; Input Bias Current: 500pA; Input Offset Voltage (Vos): 50µV; Max Dual Supply Voltage: 2.75V; Max Supply Voltage: 5.5V; Min Dual Supply Voltage: 900mV; Min Supply Voltage: 1.8V; Nominal Supply Current: 35µA; Number of Channels: 2; Operating Supply Current: 21µA; Output Current: 5mA; Slew Rate: 0.16V/µs; Voltage Gain: 115dB; Case/Package: SOIC; Category: Amplifiers - Op Amps, Buffer, Instrumentation; Component Kind: Standard; ComponentLink1Description: https://octopart.com:443/opatz8j6/c1?t=OzLtZUt0lOOF4bNdEPknYkQud8qTnPu3Z7fRiDjcVTNNv1zgi7OgSSFc4eDaYT460BHbWvigBOn4SPThUwwYX_GGxvvQq3W3qrAdTGLObgrz8FyydZ3lodpSeOaY-Nw5gKbGvX3MC6Q9HJywQ7wm4R7svpFhFRbN9WuGmSOkS27uJWcZlv61Nf_fcZ_2MpX1rvHSdOjdS3e1lUSSglsn5sZAC1c4D3RPwoLoffaq9MAgM_IswDLEKuMulbqEmmNqLEIs3NLntIeL1qUn5JFPuTvD7EMQ_o3B4zaHQ33nERXEl7PhFHyhJQaCiLxDwWibsbI4BmwMXMVU6xPW0zkWMdFYmbWXMor4eHEacddH9x_vH-f_OI-xZnKcABw5P9LVhw094_o&src-supplier=Texas+Instruments; ComponentLink1URL: https://octopart.com:443/opatz8j6/c1?t=OzLtZUt0lOOF4bNdEPknYkQud8qTnPu3Z7fRiDjcVTNNv1zgi7OgSSFc4eDaYT460BHbWvigBOn4SPThUwwYX_GGxvvQq3W3qrAdTGLObgrz8FyydZ3lodpSeOaY-Nw5gKbGvX3MC6Q9HJywQ7wm4R7svpFhFRbN9WuGmSOkS27uJWcZlv61Nf_fcZ_2MpX1rvHSdOjdS3e1lUSSglsn5sZAC1c4D3RPwoLoffaq9MAgM_IswDLEKuMulbqEmmNqLEIs3NLntIeL1qUn5JFPuTvD7EMQ_o3B4zaHQ33nERXEl7PhFHyhJQaCiLxDwWibsbI4BmwMXMVU6xPW0zkWMdFYmbWXMor4eHEacddH9x_vH-f_OI-xZnKcABw5P9LVhw094_o&src-supplier=Texas+Instruments; ComponentLink2Description: https://datasheet.octopart.com:443/OPA2330AIDR-Texas-Instruments-datasheet-37575452.pdf?src-supplier=Texas+Instruments; ComponentLink2URL: https://datasheet.octopart.com:443/OPA2330AIDR-Texas-Instruments-datasheet-37575452.pdf?src-supplier=Texas+Instruments; ComponentLink3Description: https://sigma.octopart.com:443/49249642/technical_drawing/Texas-Instruments-OPA2330AIDR.pdf?src-supplier=Texas+Instruments; ComponentLink3URL: https://sigma.octopart.com:443/49249642/technical_drawing/Texas-Instruments-OPA2330AIDR.pdf?src-supplier=Texas+Instruments; ComponentLink4Description: https://datasheet.octopart.com:443/OPA2330AIDR-Texas-Instruments-datasheet-101600495.pdf?src-supplier=Upverter; ComponentLink4URL: https://datasheet.octopart.com:443/OPA2330AIDR-Texas-Instruments-datasheet-101600495.pdf?src-supplier=Upverter; ComponentLink5Description: https://datasheet.octopart.com:443/OPA2330AIDR-Texas-Instruments-datasheet-17021843.pdf?src-supplier=Newark; ComponentLink5URL: https://datasheet.octopart.com:443/OPA2330AIDR-Texas-Instruments-datasheet-17021843.pdf?src-supplier=Newark; ComponentLink6Description: https://datasheet.octopart.com:443/OPA2330AIDR-Texas-Instruments-datasheet-164415936.pdf?src-supplier=LCSC; ComponentLink6URL: https://datasheet.octopart.com:443/OPA2330AIDR-Texas-Instruments-datasheet-164415936.pdf?src-supplier=LCSC; ComponentLink7Description: https://datasheet.octopart.com:443/OPA2330AIDR-Texas-Instruments-datasheet-159115572.pdf?src-supplier=LCSC; ComponentLink7URL: https://datasheet.octopart.com:443/OPA2330AIDR-Texas-Instruments-datasheet-159115572.pdf?src-supplier=LCSC; ComponentLink8Description: https://datasheet.octopart.com:443/OPA2330AIDR-Texas-Instruments-datasheet-84208676.pdf?src-supplier=Farnell; ComponentLink8URL: https://datasheet.octopart.com:443/OPA2330AIDR-Texas-Instruments-datasheet-84208676.pdf?src-supplier=Farnell; ComponentLink9Description: https://datasheet.octopart.com:443/OPA2330AIDR-Texas-Instruments-datasheet-137316104.pdf?src-supplier=Future+Electronics; ComponentLink9URL: https://datasheet.octopart.com:443/OPA2330AIDR-Texas-Instruments-datasheet-137316104.pdf?src-supplier=Future+Electronics; ComponentLink10Description: https://datasheet.octopart.com:443/OPA2330AIDR-Texas-Instruments-datasheet-14138665.pdf?src-supplier=iiiC; ComponentLink10URL: https://datasheet.octopart.com:443/OPA2330AIDR-Texas-Instruments-datasheet-14138665.pdf?src-supplier=iiiC; ComponentLink11Description: http://sigma.octopart.com:80/reach_statement/Texas-Instruments-company-64.pdf?src-supplier=Compliance+Statements; ComponentLink11URL: http://sigma.octopart.com:80/reach_statement/Texas-Instruments-company-64.pdf?src-supplier=Compliance+Statements; ComponentLink12Description: http://sigma.octopart.com:80/conflict_mineral_statement/Texas-Instruments-company-63.pdf?src-supplier=Compliance+Statements; ComponentLink12URL: http://sigma.octopart.com:80/conflict_mineral_statement/Texas-Instruments-company-63.pdf?src-supplier=Compliance+Statements; Contact Plating: Gold; Gain Bandwidth Product: 350kHz; Height: 1.75mm; Lead Free: Lead Free; Length: 4.9mm; Library Name: ENGG3800 schematic library.SchLib; Lifecycle Status: Production; Manufacturer Part Number: OPA2330AIDR; Max I/O Voltage: 50µV; Max Operating Temperature: 125°C; Min Operating Temperature: -40°C; Number of Circuits: 2; Number of Elements: 2; Output Current per Channel: 5mA; Pins: 8; Pricing: 1=1.324, 10=1.322, 25=1.321, 100=1.32, 250=1.319, 500=1.318 (USD); Radiation Hardening: No; RoHS: Compliant; Schedule B: 8542330000, 8542330000|8542330000|8542330000|8542330000|8542330000; Stock: 516; Supplier 1: Digikey; Supplier Part Number 1: 296-25305-1-ND; Thickness: 1.58mm; Width: 3.91mm
